# Altium schematic sheet Power.SchDoc
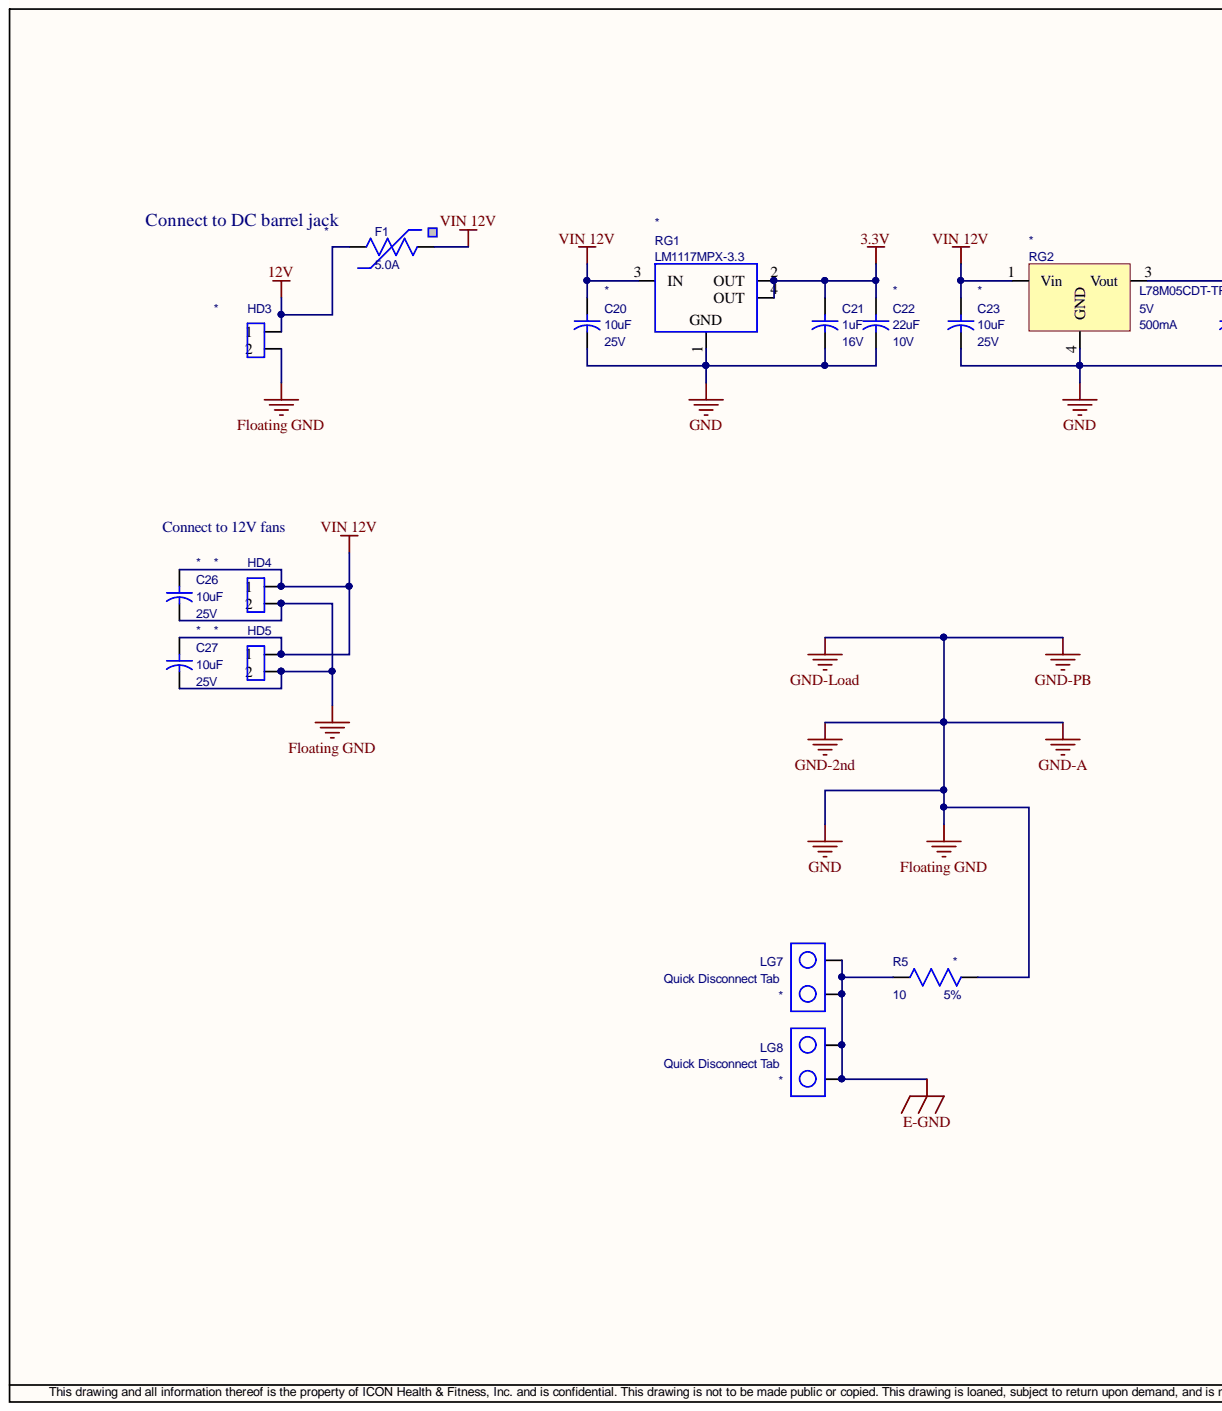
[diagram p0: Power.SchDoc, left]
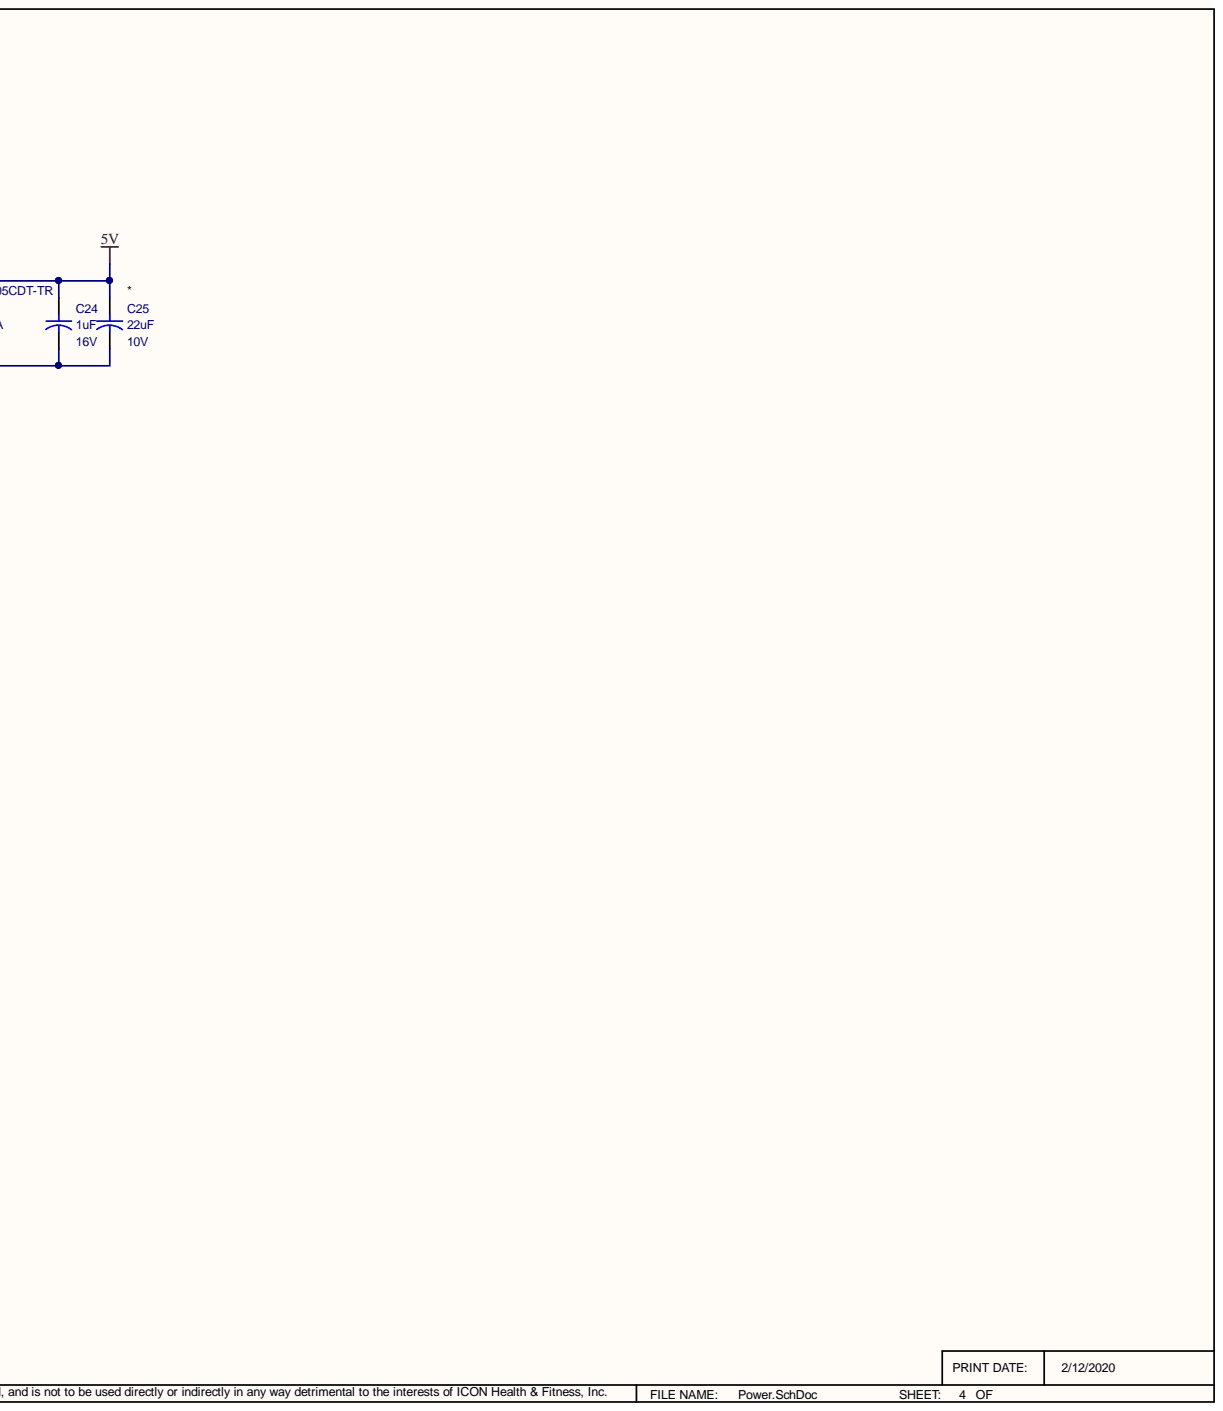
[diagram p1: Power.SchDoc, right]

## Parts
C20  value 10uF  Voltage: 25V  [C0603]  (hidden comment: CAP_NON_POLAR_SMT)
C21  value 1uF  Voltage: 16V  [0402]  (hidden comment: 1uF_0402)
C22  value 22uF  Voltage: 10V  [C0603]  (hidden comment: 22uF_Ceramic_10V)
C23  value 10uF  Voltage: 25V  [C0603]  (hidden comment: CAP_NON_POLAR_SMT)
C24  value 1uF  Voltage: 16V  [0402]  (hidden comment: 1uF_0402)
C25  value 22uF  Voltage: 10V  [C0603]  (hidden comment: 22uF_Ceramic_10V)
C26  value 10uF  Voltage: 25V  [C0603]  (hidden comment: CAP_NON_POLAR_SMT)
C27  value 10uF  Voltage: 25V  [C0603]  (hidden comment: CAP_NON_POLAR_SMT)
F1  value 5.0A  [PTC_200]  (hidden comment: FUSE_PTC_16V_5A_UL)
HD3  HDR2PIN  [HDR2PIN_JST_XA_2.5MM]  (value not drawn)
HD4  HDR2PIN  [HDR2PIN_JST_XA_2.5MM]  (value not drawn)
HD5  HDR2PIN  [HDR2PIN_JST_XA_2.5MM]  (value not drawn)
LG7  value Quick Disconnect Tab  [SPADE_0.25]  (hidden comment: SPADE_LUG)
LG8  value Quick Disconnect Tab  [SPADE_0.25]  (hidden comment: SPADE_LUG)
R5  value 10  Tolerance: 5%  [RC2010REFLOW]  (hidden comment: R2010REFLOW_5%)
RG1  value LM1117MPX-3.3  [SOT-223]  (hidden comment: REGULATOR_FIXED_SOT223)
RG2  value L78M05CDT-TR  Amperage: 500mA  Voltage: 5V  [DPAK]  (hidden comment: REGULATOR_DPAK)

## Nets
3.3V: C21.1 C22.1 RG1.2(OUT) RG1.4(OUT)
5V: C24.1 C25.1 RG2.3
12V: F1.2 HD3.1
E-GND: LG7.1 LG7.2 LG8.1 LG8.2 R5.2
Floating GND: C20.2 C21.2 C22.2 C23.2 C24.2 C25.2 C26.2 C27.2 HD3.2 HD4.2 HD5.2 R5.1 RG1.1 RG2.4
VIN 12V: C20.1 C23.1 C26.1 C27.1 F1.1 HD4.1 HD5.1 RG1.3(IN) RG2.1

## Library data of the parts
C20 C23 C26 C27 = 296633: Capacitor, 10uF, 25V, Ceramic, X5R, ±20%, Non-Polar, 0603, MURATA: GRM188R61E106MA73J or equivalent; Database Table Name: AltiumComponents; CapType: Ceramic; Component Kind: Standard; ComponentLink1Description: Datasheet; ComponentLink1URL: \\iconfitness.com\loganfiles\RND Departments\electronics\accel_lib\Datasheets\c02e.pdf; ComponentLink4Description: PDM Parts Maintenance; ComponentLink4URL: https://pdm.iconfitness.com/PDM/EBOM/PartsMaintenance/PartsMaintenance.aspx?Editplidx=296633; ComponentType: Capacitor; Composition: X5R; Cost: 0.02; Package: 0603; plIDX: 296633; Polarity: Non-Polar; Tolerance: ±20%; Vendor: MURATA: GRM188R61E106MA73J or equivalent; CheckedBy: Sam Rhoufiry; CreatedBy: Michael Angeli; LastUpdatedBy: Steven Zhou; Library Name: bome.DbLib
C21 C24 = 295904: Capacitor, 1uF, 16V, Monolithic, +/- 5%, Non-Polar, 0402, 2; Database Table Name: AltiumComponents; Tolerance: +/- 5%; CapType: Monolithic; Component Kind: Standard; ComponentLink1Description: Datasheet; ComponentLink4Description: PDM Parts Maintenance; ComponentLink4URL: https://pdm.iconfitness.com/PDM/EBOM/PartsMaintenance/PartsMaintenance.aspx?Editplidx=295904; ComponentType: Capacitor; Cost: 0.03; ModifyDate: 4/13/10; Package: 0402; Pins: 2; plIDX: 295904; Polarity: Non-Polar; CheckedBy: gdeguzman; CreatedBy: Brian Beardall; LastUpdatedBy: Brian Beardall; Library Name: bome.DbLib
C22 C25 = 323743: Capacitor, 22uF, 10V, Ceramic, ±20%, Non-Polar, 0603, 2, Murata: GRM188R61A226ME15D or equivalent; Database Table Name: AltiumComponents; Component Kind: Standard; ComponentLink1Description: Datasheet; ComponentLink1URL: \\iconfitness.com\loganfiles\RND Departments\Electronics\accel_lib\Datasheets\GRM188R61A226ME15-01.pdf; ComponentLink4Description: PDM Parts Maintenance; ComponentLink4URL: https://pdm.iconfitness.com/PDM/EBOM/PartsMaintenance/PartsMaintenance.aspx?Editplidx=323743; ComponentType: Capacitor; Composition: Ceramic; Cost: 0.12; Package: 0603; Pins: 2; plIDX: 323743; Polarity: Non-Polar; Tolerance: ±20%; Vendor: Murata: GRM188R61A226ME15D or equivalent; CheckedBy: gdeguzman; CreatedBy: Rui Zhang; LastUpdatedBy: Steven Zhou; Library Name: bome.DbLib
F1 = 3958: Fuse, 5.0A, 16V, Radial, 5A, Fuzetek: FRG500-16; Thinking: KRG0160500; Database Table Name: AltiumComponents; Notes: Must be listed to UL XGPU2 and XGPU8; Amperage: 5A; Component Kind: Standard; ComponentLink4Description: PDM Parts Maintenance; ComponentLink4URL: https://pdm.iconfitness.com/PDM/EBOM/PartsMaintenance/PartsMaintenance.aspx?Editplidx=3958; ComponentType: Fuse; Cost: 0.25; Datasheet: g:/accel_lib/datasheets/FRG_fuzetek_RFE.PDF; Package: Radial; plIDX: 3958; Vendor: Fuzetek: FRG500-16; Thinking: KRG0160500; Voltage: 16V; CheckedBy: B. Lyon; LastUpdatedBy: Mike Tyger; Library Name: bome.DbLib
HD3-HD5 = 342208: Header, 2 Pins, 2.50mm, Vertical, Through hole, single row, Shrouded, JST: B02B-XASK-1-A; Database Table Name: AltiumComponents; Component Kind: Standard; ComponentLink1Description: Datasheet; ComponentLink1URL: \\iconfitness.com\loganfiles\RND Departments\electronics\accel_lib\Datasheets\eXA1.pdf; ComponentLink4Description: PDM Parts Maintenance; ComponentLink4URL: https://pdm.iconfitness.com/PDM/EBOM/PartsMaintenance/PartsMaintenance.aspx?Editplidx=342208; ComponentType: Header; Cost: 0.0446; HeaderType: Shrouded; LeadSpacing: 2.50mm; Package: Vertical, Through hole, single row; Pins: 2; plIDX: 342208; Vendor: JST: B02B-XASK-1-A; CreatedBy: Michael Angeli; LastUpdatedBy: Michael Angeli; Library Name: bome.DbLib
LG7 LG8 = 265185: Header, 0.25", Ting Zi: 025-3489-01 or equivalent; Database Table Name: AltiumComponents; Component Kind: Standard; ComponentLink1Description: Datasheet; ComponentLink1URL: \\iconfitness.com\loganfiles\RND Departments\Electronics\accel_lib\025-3489-01.pdf; ComponentLink4Description: PDM Parts Maintenance; ComponentLink4URL: https://pdm.iconfitness.com/PDM/EBOM/PartsMaintenance/PartsMaintenance.aspx?Editplidx=265185; ComponentType: Header; Cost: 0.0125; HeaderType: 0.25"; plIDX: 265185; Vendor: Ting Zi: 025-3489-01 or equivalent; CheckedBy: gdeguzman; CreatedBy: Mike Tyger; LastUpdatedBy: Mike Tyger; Library Name: bome.DbLib
R5 = 16099: Resistor, 10, ThickFilm, 5%, 2010, 1/2W, Any; Database Table Name: AltiumComponents; Component Kind: Standard; ComponentLink4Description: PDM Parts Maintenance; ComponentLink4URL: https://pdm.iconfitness.com/PDM/EBOM/PartsMaintenance/PartsMaintenance.aspx?Editplidx=16099; ComponentType: Resistor; Composition: ThickFilm; Cost: 0.001; ModifyDate: 03/02/2004 17:13:14; Package: 2010; plIDX: 16099; Vendor: Any; Wattage: 1/2W; CheckedBy: Allen Hansen; Library Name: bome.DbLib
RG1 = 18870: Regulator, LM1117MPX-3.3, 3.3V, SOT-223, 800mA (min), LDO, TI: LM1117MPX-3.3/NOPB, Diodes Inc: AZ1117CH-3.3TRG1, Diodes Inc: AZ1117IH-3.3TRG1, ST: LD1117S33, Infineon: IFX1117MEV33, or equivalent; Database Table Name: AltiumComponents; Amperage: 800mA (min); Component Kind: Standard; ComponentLink1Description: Datasheet; ComponentLink1URL: \\iconfitness.com\loganfiles\RND Departments\electronics\accel_lib\DatasheetsLM1117-national.pdf; ComponentLink4Description: PDM Parts Maintenance; ComponentLink4URL: https://pdm.iconfitness.com/PDM/EBOM/PartsMaintenance/PartsMaintenance.aspx?Editplidx=18870; ComponentType: Regulator; Cost: 0.2; Package: SOT-223; plIDX: 18870; RegulatorType: LDO; Vendor: TI: LM1117MPX-3.3/NOPB, Diodes Inc: AZ1117CH-3.3TRG1, Diodes Inc: AZ1117IH-3.3TRG1, ST: LD1117S33, Infineon: IFX1117MEV33, or equivalent; Voltage: 3.3V; LastUpdatedBy: Mike Tyger; Library Name: bome.DbLib
RG2 = 265303: Regulator, L78M05CDT-TR, 5V, DPAK, 500mA, Linear, ST: L78M05CDT-TR or equivalent; Database Table Name: AltiumComponents; Component Kind: Standard; ComponentLink4Description: PDM Parts Maintenance; ComponentLink4URL: https://pdm.iconfitness.com/PDM/EBOM/PartsMaintenance/PartsMaintenance.aspx?Editplidx=265303; ComponentType: Regulator; Cost: 0.05; Package: DPAK; plIDX: 265303; RegulatorType: Linear; Vendor: ST: L78M05CDT-TR or equivalent; CheckedBy: B. Lyon; CreatedBy: Mike Tyger; LastUpdatedBy: Mike Tyger; Library Name: bome.DbLib
